# Altium schematic sheet H-BRIDGE.SchDoc
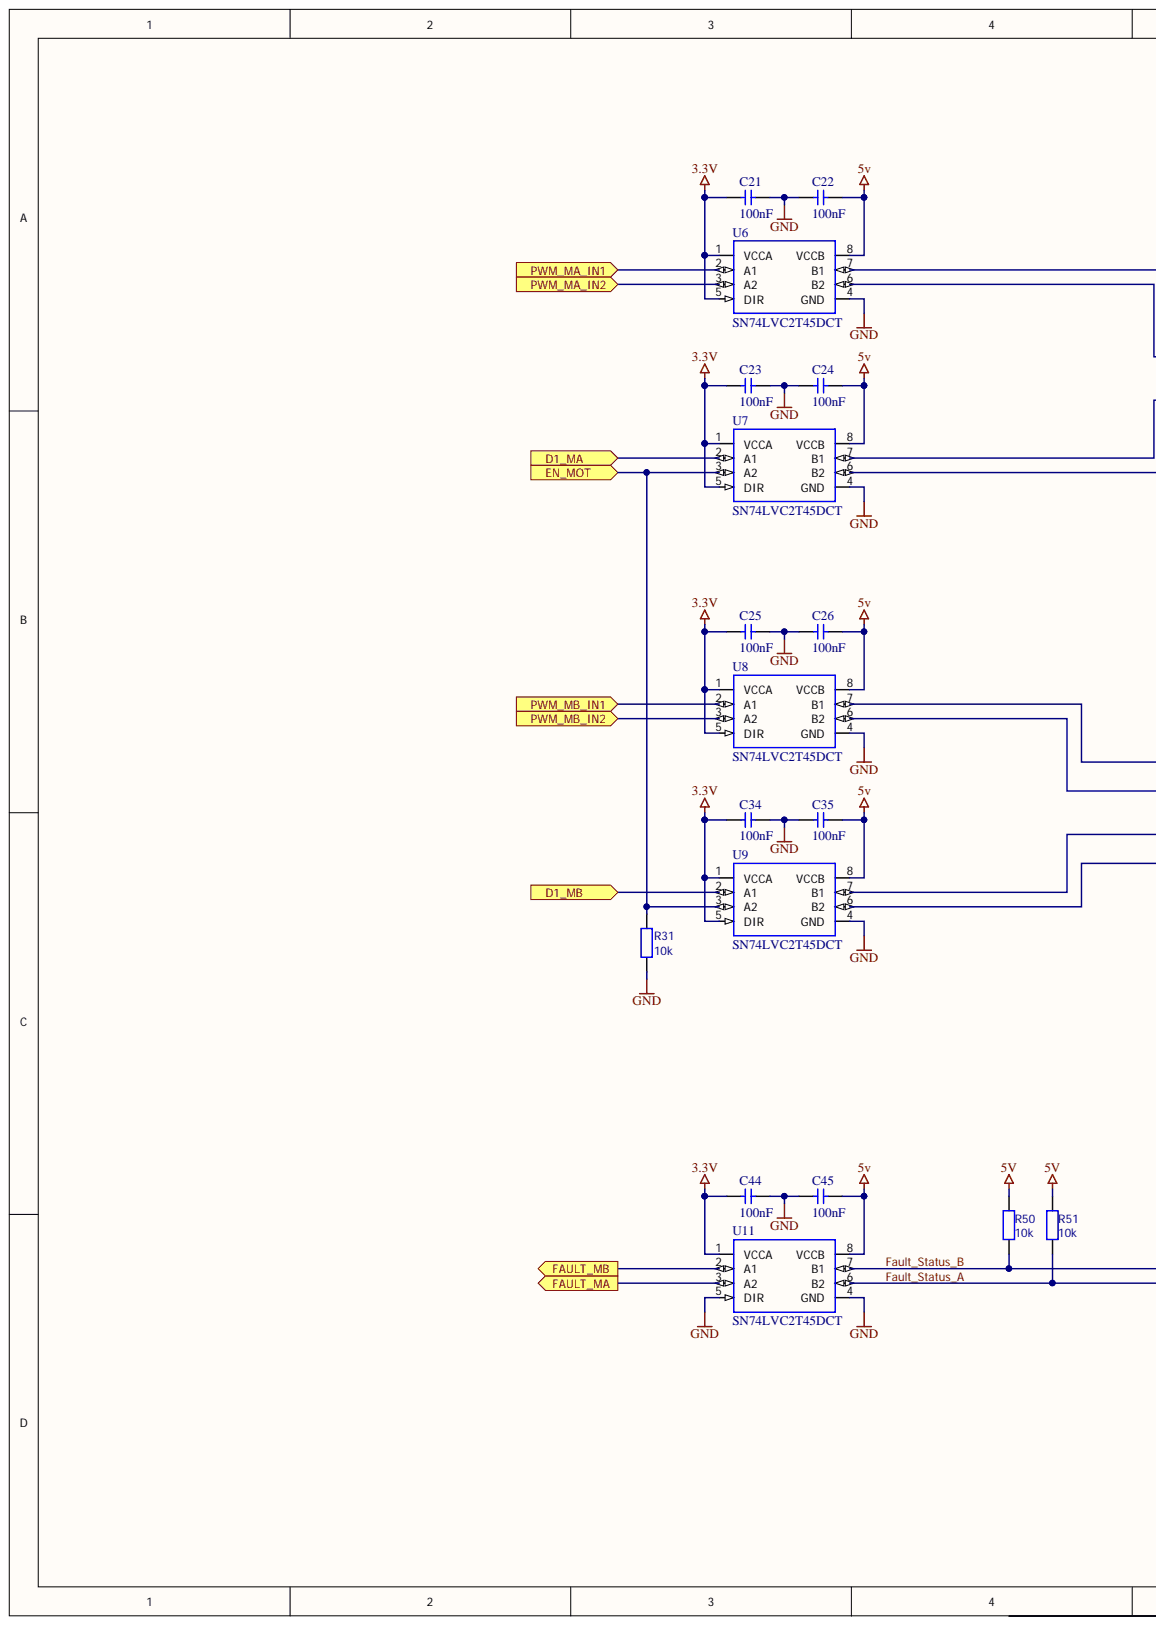
[diagram p0: H-BRIDGE.SchDoc, left]
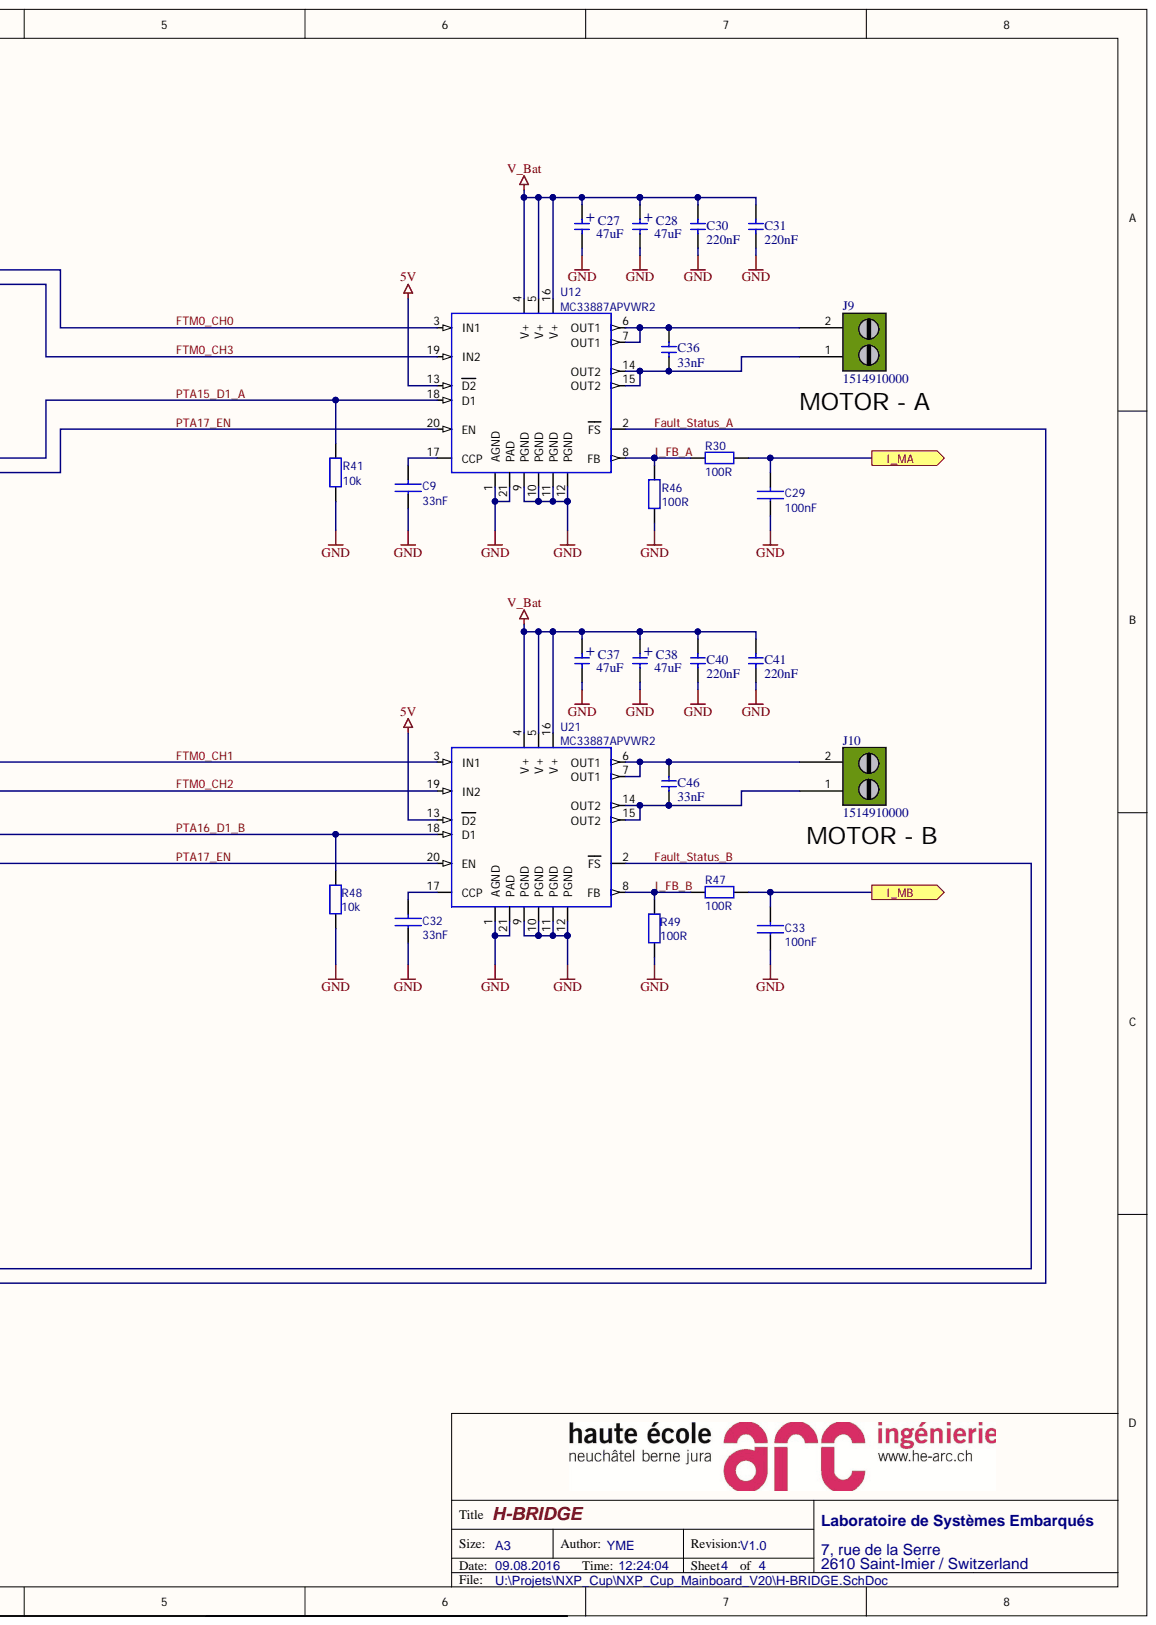
[diagram p1: H-BRIDGE.SchDoc, right]

## Parts
C9  33nF  [060301]
C21  100nF  [060301]
C22  100nF  [060301]
C23  100nF  [060301]
C24  100nF  [060301]
C25  100nF  [060301]
C26  100nF  [060301]
C27  value 47uF  [PANASONIC_D]  (hidden comment: EEH-ZA1V470P)
C28  value 47uF  [PANASONIC_D]  (hidden comment: EEH-ZA1V470P)
C29  100nF  [060301]
C30  220nF  [060301]
C31  220nF  [060301]
C32  33nF  [060301]
C33  100nF  [060301]
C34  100nF  [060301]
C35  100nF  [060301]
C36  33nF  [060301]
C37  value 47uF  [PANASONIC_D]  (hidden comment: EEH-ZA1V470P)
C38  value 47uF  [PANASONIC_D]  (hidden comment: EEH-ZA1V470P)
C40  220nF  [060301]
C41  220nF  [060301]
C44  100nF  [060301]
C45  100nF  [060301]
C46  33nF  [060301]
J9  1514910000  [SL508_2C]
J10  1514910000  [SL508_2C]
R30  100R  [060301]
R31  10k  [060301]
R41  10k  [060301]
R46  100R  [060301]
R47  100R  [060301]
R48  10k  [060301]
R49  100R  [060301]
R50  10k  [060301]
R51  10k  [060301]
U6  SN74LVC2T45DCT  [Micro8]
U7  SN74LVC2T45DCT  [Micro8]
U8  SN74LVC2T45DCT  [Micro8]
U9  SN74LVC2T45DCT  [Micro8]
U11  SN74LVC2T45DCT  [Micro8]
U12  MC33887APVWR2  [HSOP20]
U21  MC33887APVWR2  [HSOP20]

## Ports
D1_MA, D1_MB, EN_MOT, FAULT_MA, FAULT_MB, I_MA, I_MB, PWM_MA_IN1, PWM_MA_IN2, PWM_MB_IN1, PWM_MB_IN2

## Nets
3.3V: C21.1 C23.1 C25.1 C34.1 C44.1 U6.1(VCCA) U6.5(DIR) U7.1(VCCA) U7.5(DIR) U8.1(VCCA) U8.5(DIR) U9.1(VCCA) U9.5(DIR) U11.1(VCCA)
5V: C22.2 C24.2 C26.2 C35.2 C45.2 R50.2 R51.2 U6.8(VCCB) U7.8(VCCB) U8.8(VCCB) U9.8(VCCB) U11.8(VCCB) U12.13(D2) U21.13(D2)
GND: C9.1 C21.2 C22.1 C23.2 C24.1 C25.2 C26.1 C27.2 C28.2 C29.1 C30.1 C31.1 C32.1 C33.1 C34.2 C35.1 C37.2 C38.2 C40.1 C41.1 C44.2 C45.1 R31.1 R41.1 R46.2 R48.1 R49.2 U6.4(GND) U7.4(GND) U8.4(GND) U9.4(GND) U11.4(GND) U11.5(DIR) U12.1(AGND) U12.9(PGND) U12.10(PGND) U12.11(PGND) U12.12(PGND) U12.21(PAD) U21.1(AGND) U21.9(PGND) U21.10(PGND) U21.11(PGND) U21.12(PGND) U21.21(PAD)
V_BAT: C27.1 C28.1 C30.2 C31.2 C37.1 C38.1 C40.2 C41.2 U12.4(V+) U12.5(V+) U12.16(V+) U21.4(V+) U21.5(V+) U21.16(V+)
D1_MA: U7.2(A1) ; port D1_MA
D1_MB: U9.2(A1) ; port D1_MB
EN_MOT: R31.2 U7.3(A2) U9.3(A2) ; port EN_MOT
FAULT_MA: U11.3(A2) ; port FAULT_MA
FAULT_MB: U11.2(A1) ; port FAULT_MB
FAULT_STATUS_A: R51.1 U11.6(B2) U12.2(FS)
FAULT_STATUS_B: R50.1 U11.7(B1) U21.2(FS)
FTM0_CH0: U6.7(B1) U12.3(IN1)
FTM0_CH1: U8.7(B1) U21.3(IN1)
FTM0_CH2: U8.6(B2) U21.19(IN2)
FTM0_CH3: U6.6(B2) U12.19(IN2)
I_FB_A: R30.1 R46.1 U12.8(FB)
I_FB_B: R47.1 R49.1 U21.8(FB)
I_MA: C29.2 R30.2 ; port I_MA
I_MB: C33.2 R47.2 ; port I_MB
PTA15_D1_A: R41.2 U7.7(B1) U12.18(D1)
PTA16_D1_B: R48.2 U9.7(B1) U21.18(D1)
PTA17_EN: U7.6(B2) U9.6(B2) U12.20(EN) U21.20(EN)
PWM_MA_IN1: U6.2(A1) ; port PWM_MA_IN1
PWM_MA_IN2: U6.3(A2) ; port PWM_MA_IN2
PWM_MB_IN1: U8.2(A1) ; port PWM_MB_IN1
PWM_MB_IN2: U8.3(A2) ; port PWM_MB_IN2
NetC9_2: C9.2 U12.17(CCP)
NetC32_2: C32.2 U21.17(CCP)
NetC36_1: C36.1 J9.1 U12.14(OUT2) U12.15(OUT2)
NetC36_2: C36.2 J9.2 U12.6(OUT1) U12.7(OUT1)
NetC46_1: C46.1 J10.1 U21.14(OUT2) U21.15(OUT2)
NetC46_2: C46.2 J10.2 U21.6(OUT1) U21.7(OUT1)

## Library data of the parts
C9 C32 = CAPA: CAP CER 0.033UF 25V 10% X7R 0603; Component Kind: Standard; Supplier 1: Digi-Key; Supplier Part Number 1: 490-1521-1-ND; Value: 33nF; Library Name: EISI.SchLib
C21-C26 C34 C35 C44 C45 = GRM188R71H104KA93D: CAP CER 0.1UF 25V 10% X7R 0603; Component Kind: Standard; Library Name: eCapacitors.SchLib; Supplier 1: Digi-Key; Supplier Part Number 1: 445-1316-1-ND; Value: 100nF; Voltage: 25V
C27 C28 C37 C38 = EEE-1EA470WP: CAP ALUM 47UF 25V 20% SMD; Voltage: 35V; Component Kind: Standard; Library Name: eCapacitors.SchLib; Supplier 1: Digi-Key; Supplier Part Number 1: P16513CT-ND
C29 C33 = CAPA: CAP CER 0.1UF 25V 10% X7R 0603; Component Kind: Standard; Supplier 1: Digi-Key; Supplier Part Number 1: 445-1316-1-ND; Value: 100nF; Voltage: 25V; Library Name: EISI.SchLib
C30 C31 C40 C41 = GRM188R71H333KA61D: CAP CER 0.22UF 25V 10% X5R 0603; Voltage: 50V; Component Kind: Standard; Library Name: eCapacitors.SchLib; Supplier 1: Digi-Key; Supplier Part Number 1: 445-5140-1-ND; Value: 220nF
C36 C46 = GRM188R71H333KA61D: CAP CER 0.033UF 25V 10% X7R 0603; Component Kind: Standard; Library Name: eCapacitors.SchLib; Supplier 1: Digi-Key; Supplier Part Number 1: 490-1521-1-ND; Value: 33nF
J9 = 282857-2: TERM BLOCK HDR 2POS R/A 5.08MM; Component Kind: Standard; Library Name: eConnector.SchLib; Supplier 1: Digi-Key; Supplier Part Number 1: 281-1279-ND
J10 = 282857-2: TERM BLOCK HDR 2POS R/A 5.08MM; Voltage: 300V; Component Kind: Standard; Library Name: eConnector.SchLib; Supplier 1: Digi-Key; Supplier Part Number 1: 281-1279-ND; Value: 282857-2
R30 R46 R47 R49 = RES: RES SMD 100 OHM 1% 1/10W 0603; Component Kind: Standard; Supplier 1: Digi-Key; Supplier Part Number 1: 311-100HRCT-ND; Value: 100R; Library Name: EISI.SchLib
R31 R41 R48 R50 R51 = RES: RES 10K OHM 1/10W .1% 0603 SMD; Component Kind: Standard; Supplier 1: Digi-Key; Supplier Part Number 1: P10KDBTR-ND; Value: 10k; Library Name: EISI.SchLib
U6-U9 U11 = SN74LVC2T45: IC BUS TRANSCVR 2BIT N-INV SM8; Component Kind: Standard; Supplier 1: Digi-Key; Supplier Part Number 1: 296-16845-1-ND; Library Name: EISI.SchLib
U12 U21 = MC33887DH: H-Bridge with current feedback; Component Kind: Standard; Library Name: KL46-Robot.SCHLIB; Supplier 1: Digi-Key; Supplier Part Number 1: MC33887APVW-ND
